# Altium schematic sheet Cell4_Management.SchDoc
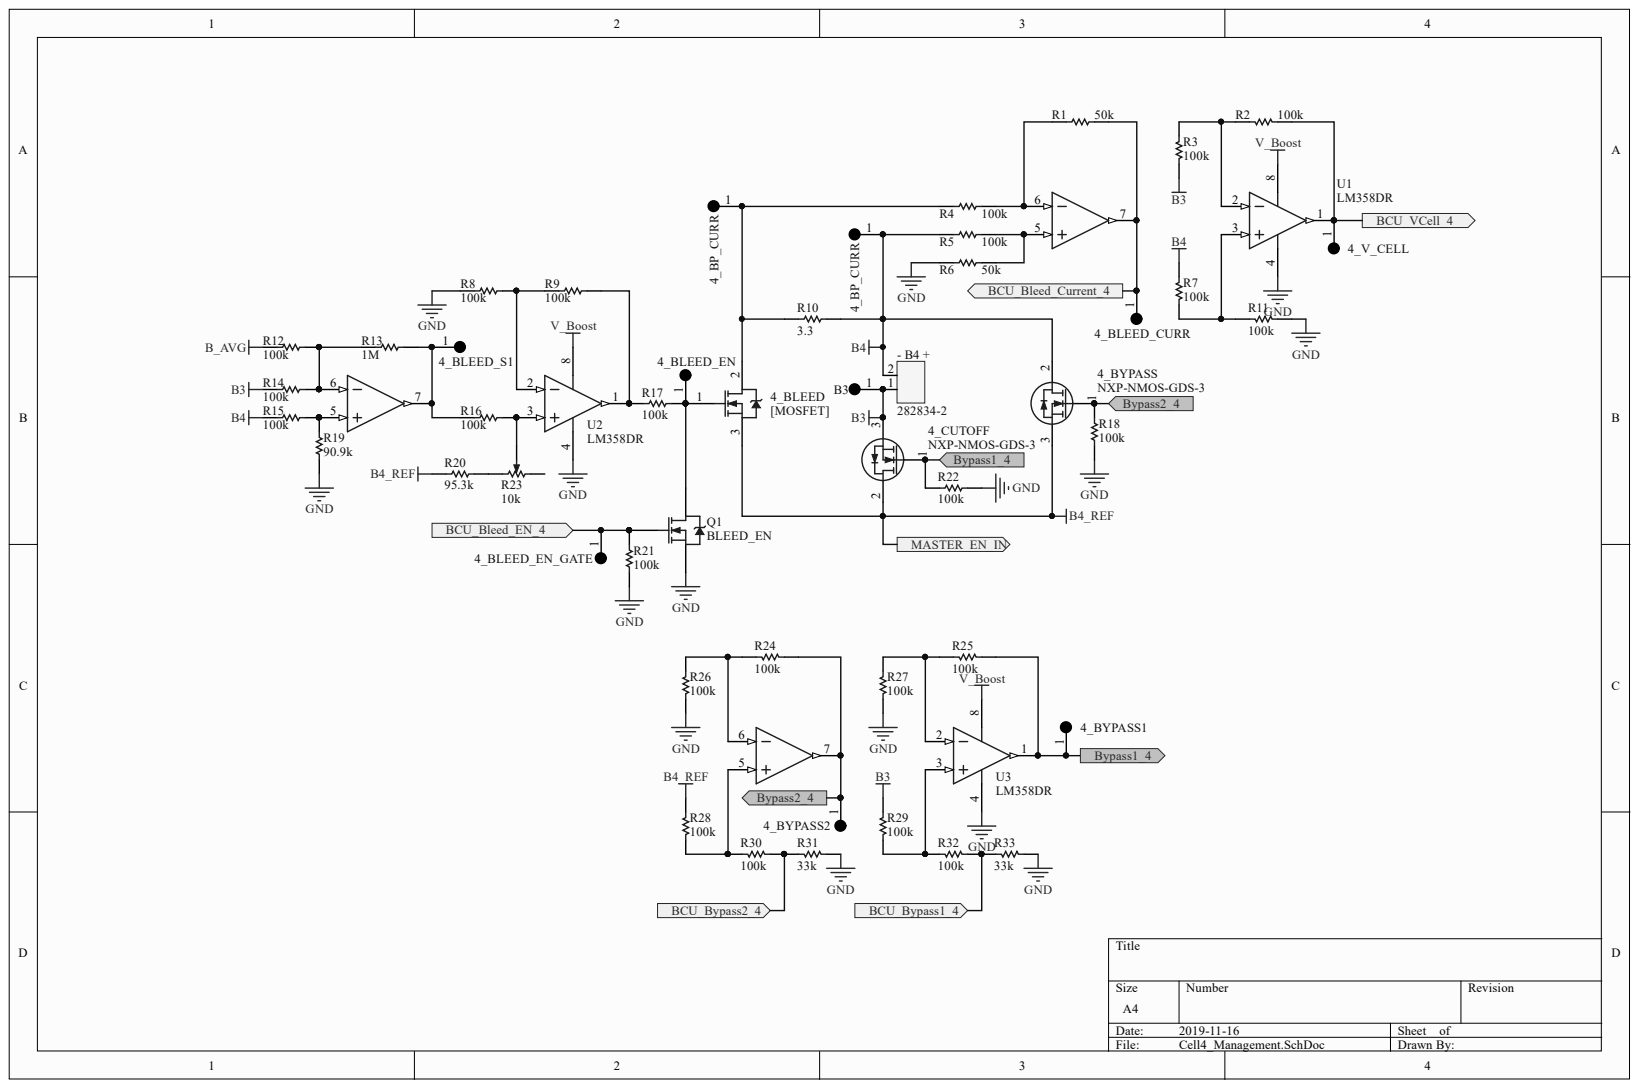
[diagram p0: Cell4_Management.SchDoc]

## Parts
4_BLEED  [MOSFET]  [PCB-8xisw4wyyimpbfb4c87f-1]
4_BLEED_CURR  Keystone 5011  [PCB-nje03k9v44gt2snsz3w9-1]  (value not drawn)
4_BLEED_EN  Keystone 5011  [PCB-nje03k9v44gt2snsz3w9-1]  (value not drawn)
4_BLEED_EN_GATE  Keystone 5011  [PCB-nje03k9v44gt2snsz3w9-1]  (value not drawn)
4_BLEED_S1  Keystone 5011  [PCB-nje03k9v44gt2snsz3w9-1]  (value not drawn)
4_BP_CURR_+  Keystone 5011  [PCB-nje03k9v44gt2snsz3w9-1]  (value not drawn)
4_BP_CURR_-  Keystone 5011  [PCB-nje03k9v44gt2snsz3w9-1]  (value not drawn)
4_BYPASS  NXP-NMOS-GDS-3  [PCB-oiskee3ochvx8romhs33-1]
4_BYPASS1  Keystone 5011  [PCB-nje03k9v44gt2snsz3w9-1]  (value not drawn)
4_BYPASS2  Keystone 5011  [PCB-nje03k9v44gt2snsz3w9-1]  (value not drawn)
4_CUTOFF  NXP-NMOS-GDS-3  [PCB-oiskee3ochvx8romhs33-1]
4_V_CELL  Keystone 5011  [PCB-nje03k9v44gt2snsz3w9-1]  (value not drawn)
-_B4_+  282834-2  [PCB-6xrngco598u3mra2uzi2-1]
B3  Keystone 5011  [PCB-nje03k9v44gt2snsz3w9-1]  (value not drawn)
Q1  BLEED_EN  [PCB-nw73lpgy3xhhfuwv1f85-1]
R1  50k  [PCB-q5zalbbyn314pt83xa2y-1]
R2  100k  [PCB-log9y0wuy6o5jvxe2v7d-1]
R3  100k  [PCB-log9y0wuy6o5jvxe2v7d-1]
R4  100k  [PCB-log9y0wuy6o5jvxe2v7d-1]
R5  100k  [PCB-log9y0wuy6o5jvxe2v7d-1]
R6  50k  [PCB-q5zalbbyn314pt83xa2y-1]
R7  100k  [PCB-log9y0wuy6o5jvxe2v7d-1]
R8  100k  [PCB-log9y0wuy6o5jvxe2v7d-1]
R9  100k  [PCB-log9y0wuy6o5jvxe2v7d-1]
R10  3.3  [PCB-kmpuyklh4rdx0el4hoky-1]
R11  100k  [PCB-log9y0wuy6o5jvxe2v7d-1]
R12  100k  [PCB-log9y0wuy6o5jvxe2v7d-1]
R13  1M  [RESC1608X55X25LL10T15]
R14  100k  [PCB-log9y0wuy6o5jvxe2v7d-1]
R15  100k  [PCB-log9y0wuy6o5jvxe2v7d-1]
R16  100k  [PCB-log9y0wuy6o5jvxe2v7d-1]
R17  100k  [PCB-8m9rktjyp1k274dv6t06-1]
R18  100k  [PCB-8m9rktjyp1k274dv6t06-1]
R19  90.9k  [PCB-7hducyzywnmpas6d05r4-1]
R20  95.3k  [PCB-5bx08r7oacs1hl5kejmf-1]
R21  100k  [PCB-8m9rktjyp1k274dv6t06-1]
R22  100k  [PCB-8m9rktjyp1k274dv6t06-1]
R23  10k  [PCB-mtzb08s5emfe72ahohl4-1]
R24  100k  [PCB-log9y0wuy6o5jvxe2v7d-1]
R25  100k  [PCB-log9y0wuy6o5jvxe2v7d-1]
R26  100k  [PCB-log9y0wuy6o5jvxe2v7d-1]
R27  100k  [PCB-log9y0wuy6o5jvxe2v7d-1]
R28  100k  [PCB-log9y0wuy6o5jvxe2v7d-1]
R29  100k  [PCB-log9y0wuy6o5jvxe2v7d-1]
R30  100k  [PCB-log9y0wuy6o5jvxe2v7d-1]
R31  33k  [PCB-a32f45k18f9nc8v92p4b-1]
R32  100k  [PCB-log9y0wuy6o5jvxe2v7d-1]
R33  33k  [PCB-a32f45k18f9nc8v92p4b-1]
U1  LM358DR  [PCB-5j1ieh3zn6lddoji8et2-1]
U2  LM358DR  [PCB-5j1ieh3zn6lddoji8et2-1]
U3  LM358DR  [PCB-5j1ieh3zn6lddoji8et2-1]

## Ports
BCU_BLEED_CURRENT_4, BCU_BLEED_EN_4, BCU_BYPASS1_4, BCU_BYPASS2_4, BCU_VCELL_4, BYPASS1_4, BYPASS2_4, MASTER_EN_IN

## Nets
B3: 4_CUTOFF.3 -_B4_+.1 B3.1 R3.2 R14.1 R29.2
B4: 4_BP_CURR_+.1 4_BYPASS.2 -_B4_+.2 R5.1 R7.2 R10.2 R15.1
B_AVG: R12.1
GND: Q1.2 R6.2 R8.1 R11.1 R18.1 R19.2 R21.1 R22.1 R26.2 R27.2 R31.1 R33.1 U1.4 U2.4 U3.4
V_BOOST: U1.8 U2.8 U3.8
B4_REF: 4_BLEED.3 4_BYPASS.3 4_CUTOFF.2 R20.1 R28.2 ; port MASTER_EN_IN
Net4_BLEED_1: 4_BLEED.1 4_BLEED_EN.1 Q1.3 R17.1
Net4_BLEED_2: 4_BLEED.2 4_BP_CURR_-.1 R4.1 R10.1
Net4_BLEED_CURR_1: 4_BLEED_CURR.1 R1.1 U1.7 ; port BCU_BLEED_CURRENT_4
Net4_BLEED_EN_GATE_1: 4_BLEED_EN_GATE.1 Q1.1 R21.2 ; port BCU_BLEED_EN_4
Net4_BLEED_S1_1: 4_BLEED_S1.1 R13.2 R16.1 U2.7
Net4_BYPASS1_1: 4_BYPASS1.1 4_CUTOFF.1 R22.2 R25.1 U3.1 ; port BYPASS1_4
Net4_BYPASS2_1: 4_BYPASS.1 4_BYPASS2.1 R18.2 R24.1 U3.7 ; port BYPASS2_4
Net4_V_CELL_1: 4_V_CELL.1 R2.1 U1.1 ; port BCU_VCELL_4
NetR1_2: R1.2 R4.2 U1.6
NetR2_2: R2.2 R3.1 U1.2
NetR5_2: R5.2 R6.1 U1.5
NetR7_1: R7.1 R11.2 U1.3
NetR8_2: R8.2 R9.1 U2.2
NetR9_2: R9.2 R17.2 U2.1
NetR12_2: R12.2 R13.1 R14.2 U2.6
NetR15_2: R15.2 R19.1 U2.5
NetR16_2: R16.2 R23.2 U2.3
NetR20_2: R20.2 R23.1
NetR24_2: R24.2 R26.1 U3.6
NetR25_2: R25.2 R27.1 U3.2
NetR28_1: R28.1 R30.1 U3.5
NetR29_1: R29.1 R32.1 U3.3
NetR30_2: R30.2 R31.2 ; port BCU_BYPASS2_4
NetR32_2: R32.2 R33.2 ; port BCU_BYPASS1_4

Not connected: R23 3

## Library data of the parts
4_BLEED = CMP-830963-4: N-channel 60 V, 0.014 Ohm typ., 35 A StripFET II Power MOSFET in a TO-220 package; Manufacturer Part Number: STP55NF06L; Breakdown Voltage (Drain to Source): 60.0 V; Breakdown Voltage [Gate to Source]: -16.0 V to 16.0 V; Continuous Drain Current (Ids): 55.0 A; Drain to Source Resistance (on) (Rds): 14.0 mΩ; Lead-Free Status: Lead Free; Power Dissipation: 95.0 W; Case/Package: TO-220; Component Kind: Standard; Current Rating: 55.0 A; Drain to Source Voltage (Vds): 60.0 V; Library Name: CommunityVault; Lifecycle Status: Active; Mounting Style: Through Hole; Number of Pins: 3; OctopartID: c4fb0df4d8ec1b3c; Octopart URL: http://octopart.com/stp55nf06l-stmicroelectronics-342385; Packaging: Tube; Polarity: N-Channel; REACH SVHC Compliance: No SVHC; RoHS: Compliant; Voltage Rating (DC): 60.0 V
4_BLEED_CURR 4_BLEED_EN 4_BLEED_EN_GATE 4_BLEED_S1 4_BP_CURR_+ 4_BP_CURR_- 4_BYPASS1 4_BYPASS2 4_V_CELL B3 = 5011: Test Point; Black; Thru-Hole; Snap-Fit; SilverPlate; 0.063in. Dia.; 0.445In.; Component Kind: Net Tie; Library Name: Octopart library
4_BYPASS 4_CUTOFF = CMP-829872-4: N-Channel Logic Level MOSFET, 30 V, 30 A, 3-Pin SOT78, Tube; Manufacturer: NXP Semiconductors; Pakcage: TO-220; Rated Voltage (Vdss): 30 V; Component Kind: Standard; Library Name: CommunityVault; Lifecycle Status: Active; Number of Pins: 3; Octopart Url: https://octopart.com/psmn022-30pl%2C127-nxp+semiconductors-18837202; Polarity: N-Channel
-_B4_+ = 282834-2: Wire-To-Board Terminal Block, 2, 150 V, 10 A, 30 Awg, 16 Awg, 1.4 Mm; ELV Compliant: Compliant; Lead-Free Status: Lead Free; Number of Contacts: 2; Wire Gauge: 16.0 AWG to 30.0 AWG; Color: Green; Component Kind: Standard; Contact Material: Brass; Contact Plating: Tin; Flammability Rating: UL 94 V-0; Library Name: Octopart library; Mounting Style: Screw, Through Hole; Octopart URL: https://octopart.com/282834-2-te+connectivity-46506870; RoHS: Compliant; Size-Width: 6.50 mm
Q1 = DMN65D8L-7: Trans MOSFET N-CH 60V 0.31A Automotive 3-Pin SOT-23 T/R; Rds On (Max) @ Id, Vgs: 3 Ohm @ 115mA, 10V; Component Kind: Standard; Library Name: Octopart library; Lifecycle Status: Active; OctopartID: b9a271de0f056665; Octopart URL: http://octopart.com/dmn65d8l-7-diodes+inc.-24548289; REACH SVHC Compliance: No SVHC
R1 R6 = ERA-3AEB4992V: RES SMD 49.9KOHM 0.1% 1/10W 0603; Manufacturer: Panasonic Electronic Components; Vendor Part Number: P49.9KDBCT-ND; Power (Watts): 0.1W, 1/10W; Resistance: 49.9k; Temperature Coefficient: ±25ppm/°C; Vendor: Digikey; Category: Resistors; Component Kind: Standard; Composition: Thin Film; Cost: 0.53; Family: Chip Resistor - Surface Mount; Features: Automotive AEC-Q200; Height: 0.022" (0.55mm); HelpURL: http://media.digikey.com/pdf/Data%20Sheets/Panasonic%20Electronic%20Components/ERA%201A,2A,3A,6A,8A.pdf; Library Name: Octopart library; Manufacturer Part Number: ERA-3AEB4992V; OctopartID: 7034ba6ea831aff3; Octopart URL: http://octopart.com/era-3aeb4992v-panasonic-55639395; Operating Temperature: -55°C ~ 155°C; Package / Case: 0603 (1608 Metric); Size / Dimension: 0.063" L x 0.031" W (1.60mm x 0.80mm); Supplier Device Package: 0603; Tolerance: ±0.1%
R2-R5 R7-R9 R11 R12 R14-R16 R24-R30 R32 = CMP-bnjbs9wz50zo2virqymb-1: RES SMD 100K OHM 0.1% 1/5W 0603; Component Kind: Standard; CustomComponentID: bnjbs9wz50zo2virqymb; Library Name: FavoritesLibrary
R10 = CMP-9119595501f04ede-2: In a Pack of 5, TE Connectivity 3.3? 5W Wire Wound Resistor ±5% ±300ppm/°C SQMW53R3J; ELV Compliant: Compliant; Lead-Free Status: Lead Free; Lead Length: 3.50 mm; Power Rating: 5.00 W; Resistance: 3.30 ?; Temperature Coefficient: -300 ppm/°C to 300 ppm/°C; Voltage Rating (DC): 350 V; Component Kind: Standard; Library Name: FavoritesLibrary; Lifecycle Status: Active; Manufacturer Part Number: SQMW53R3J; Mounting Style: Radial; OctopartID: 9119595501f04ede; Octopart URL: https://octopart.com/sqmw53r3j-te+connectivity-21411215; Operating Temperature: 275 °C (max); Packaging: Box; REACH SVHC Compliance: No SVHC; Resistance Tolerance: ±5%; RoHS: Compliant
R13 = RC0603FR-071ML: Chip Resistor, 1 MOhm, +/- 1%, 100 mW, -55 to 155 degC, 0603 (1608 Metric), RoHS, Tape and Reel; Lead-Free Status: Lead Free; Resistance: 1.00 MΩ; Component Kind: Standard; Library Name: Octopart library; Manufacturer Part Number: RC0603FR-071ML; Mounting Style: Surface Mount; OctopartID: b2787b63430c6dbf; Octopart Url: http://octopart.com/rc0603fr-071ml-yageo-1168402; PackageDescription: 2-Pin Surface Mount Device, Body 1.6 x 0.8 mm; PackageReference: 0603; Resistance Tolerance: ±1%; Seller Landing  Url: https://octopart-clicks.com/click/track?country=US&ak=8157f166&sig=0fad55c&sid=1106&ppid=1168402&vpid=198667148&ct=offers; Size-Width: 800 µm
R17 R18 R21 R22 = CRGCQ0603J100K: Res, Auto, Thick Film, 100K, 5%, 0603; Component Kind: Standard; Library Name: Octopart library; Lifecycle Status: Not Listed by Manufacturer
R19 = CMP-g689s3hq4ilknvlda8uo-1: RES SMD 90.9K OHM 0.1% 1/5W 0603; Component Kind: Standard; CustomComponentID: g689s3hq4ilknvlda8uo; Library Name: FavoritesLibrary
R20 = CMP-32d8f9a6adc0ed1f-2: Res Thick Film 0603 95.3K Ohm 1% 0.1W(1/10W) ±100ppm/C Pad SMD T/R; Manufacturer Part Number: RC0603FR-0795K3L; Case/Package (SI): 1608; Lead-Free Status: Lead Free; Power Rating: 100 mW; Resistance: 95.3 k?; Temperature Coefficient: -100 ppm/°C to 100 ppm/°C; Voltage Rating (DC): 50.0 V; Case/Package: 0603; Component Kind: Standard; Library Name: FavoritesLibrary; Mounting Style: Surface Mount; OctopartID: 32d8f9a6adc0ed1f; Octopart URL: https://octopart.com/rc0603fr-0795k3l-yageo-41204350; Operating Temperature: 155 °C (max); Packaging: Tape & Reel; Resistance Tolerance: ±1%; RoHS: Compliant; Size-Height: 450 µm; Size-Length: 1.60 mm; Size-Width: 800 µm
R23 = CMP-1e9bd3d2522ac15c-1: Res Cermet Trimmer 10K Ohm 10% 1/2W 25(Elec)Turn 2mm (9.6 X 5 X 11.3mm) Pin Thru-Hole Bulk; Lead-Free Status: Lead Free; Resistance: 10.0 kΩ; Component Kind: Standard; Library Name: CommunityVault; Octopart URL: http://octopart.com/pv36w103c01b00-bourns-71526871; REACH SVHC Compliance: No SVHC; RoHS: Compliant; Simulation: SIM-i4psbru60z8t73qiz1cu-1
R31 R33 = ERJ3EKF3302V: Res Thick Film 0603 33K Ohm 1% 0.1W(1/10W) ±100ppm/C Molded SMD Automotive Punched T/R; Manufacturer Part Number: ERJ-3EKF3302V; Lead-Free Status: Lead Free; Power Rating: 100 mW; Resistance: 33 KΩ; Voltage Rating (DC): 75.0 V; Case/Package: 0603; Component Kind: Standard; Library Name: Octopart library; Lifecycle Status: Not Listed by Manufacturer; Mounting Style: Surface Mount; Number of Pins: 2; OctopartID: 11b7d802b8e0ce70; Octopart URL: http://octopart.com/erj-3ekf3302v-panasonic-12553265; Packaging: Tape & Reel (TR), Cut Tape (CT); REACH SVHC Compliance: No SVHC; Resistance Tolerance: ±1%; RoHS: Compliant
U1-U3 = CMP-4gajgemt6408qmd47hs9-2: 8-SOIC Dual Op-Amp; Component Kind: Standard; CustomComponentID: 4gajgemt6408qmd47hs9; Library Name: FavoritesLibrary
